# Altium schematic sheet BaseModuleTop.SchDoc
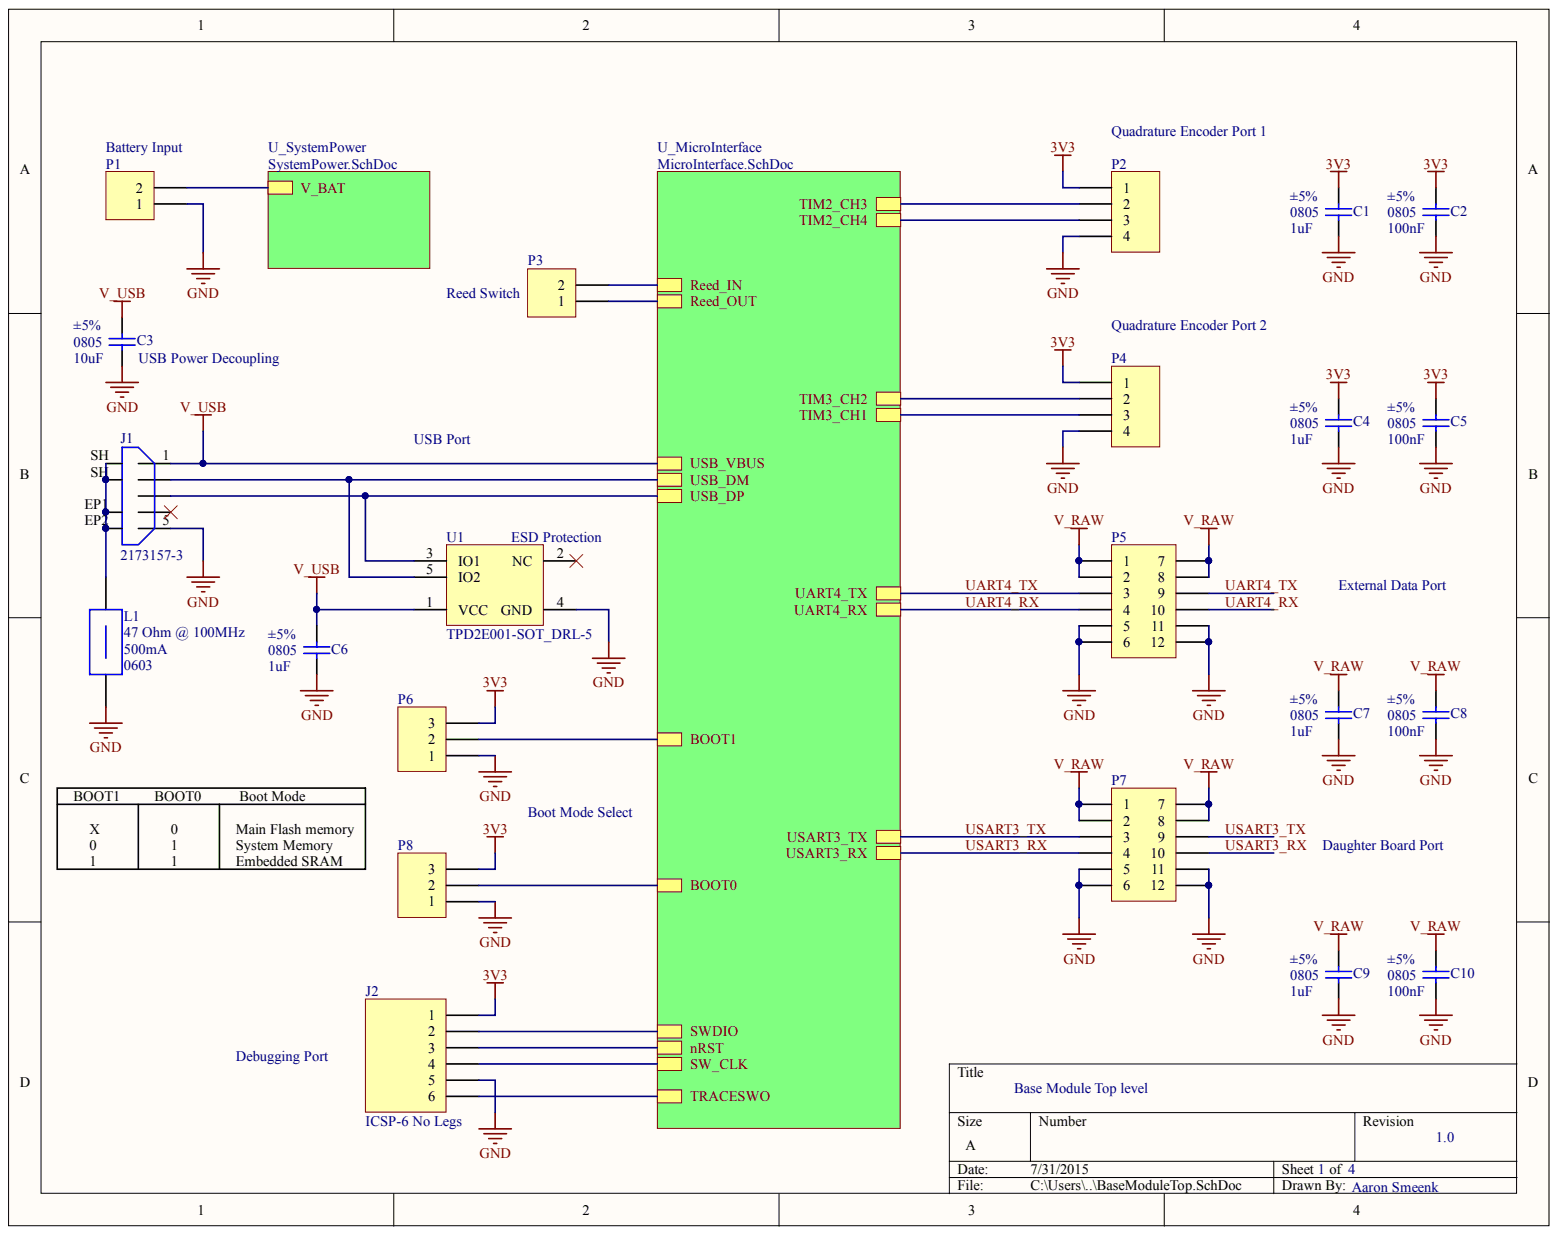
[diagram p0: BaseModuleTop.SchDoc]

## Parts
C1  value 1uF  Case-EIA: 0805  Tolerance: ±5%  [CAPC0805(2012)100_L]  (hidden comment: CAP 1uF 6.3V 0805(2012))
C2  value 100nF  Case-EIA: 0805  Tolerance: ±5%  [CAPC0805(2012)100_L]  (hidden comment: CAP 100nF 6.3V 0805(2012))
C3  value 10uF  Case-EIA: 0805  Tolerance: ±5%  [CAPC0805(2012)100_L]  (hidden comment: CAP 10uF 6.3V 0805(2012))
C4  value 1uF  Case-EIA: 0805  Tolerance: ±5%  [CAPC0805(2012)100_L]  (hidden comment: CAP 1uF 6.3V 0805(2012))
C5  value 100nF  Case-EIA: 0805  Tolerance: ±5%  [CAPC0805(2012)100_L]  (hidden comment: CAP 100nF 6.3V 0805(2012))
C6  value 1uF  Case-EIA: 0805  Tolerance: ±5%  [CAPC0805(2012)100_L]  (hidden comment: CAP 1uF 6.3V 0805(2012))
C7  value 1uF  Case-EIA: 0805  Tolerance: ±5%  [CAPC0805(2012)100_L]  (hidden comment: CAP 1uF 6.3V 0805(2012))
C8  value 100nF  Case-EIA: 0805  Tolerance: ±5%  [CAPC0805(2012)100_L]  (hidden comment: CAP 100nF 6.3V 0805(2012))
C9  value 1uF  Case-EIA: 0805  Tolerance: ±5%  [CAPC0805(2012)100_L]  (hidden comment: CAP 1uF 6.3V 0805(2012))
C10  value 100nF  Case-EIA: 0805  Tolerance: ±5%  [CAPC0805(2012)100_L]  (hidden comment: CAP 100nF 6.3V 0805(2012))
J1  2173157-3  [2173157-3]
J2  ICSP-6 No Legs  [TC2030-IDC-NL]
L1  Current Rating: 500mA  Package / Case: 0603  [LI0603E470R-10]  (hidden comment: LI0603E470R-10)
P1  Header 2  [HDR1X2]  (value not drawn)
P2  Header 4  [HDR1X4]  (value not drawn)
P3  Header 2  [HDR1X2]  (value not drawn)
P4  Header 4  [HDR1X4]  (value not drawn)
P5  Header 6X2A  [HDR2X6_CEN]  (value not drawn)
P6  Header 3  [HDR1X3]  (value not drawn)
P7  Header 6X2A  [HDR2X6_CEN]  (value not drawn)
P8  Header 3  [HDR1X3]  (value not drawn)
U1  TPD2E001-SOT_DRL-5  [TPD2E001DRLR]

## Nets
3V3: C1.2 C2.2 C4.2 C5.2 J2.1 P2.1 P4.1 P6.3 P8.3
GND: C1.1 C2.1 C3.1 C4.1 C5.1 C6.1 C7.1 C8.1 C9.1 C10.1 J1.5 J2.5 L1.1 P1.1 P2.4 P4.4 P5.5 P5.6 P5.11 P5.12 P6.1 P7.5 P7.6 P7.11 P7.12 P8.1 U1.4(GND)
V_RAW: C7.2 C8.2 C9.2 C10.2 P5.1 P5.2 P5.7 P5.8 P7.1 P7.2 P7.7 P7.8
V_USB: C3.2 C6.2 J1.1 U1.1(VCC)
UART4_RX: P5.4 P5.10
UART4_TX: P5.3 P5.9
USART3_RX: P7.4 P7.10
USART3_TX: P7.3 P7.9
NetJ1_2: J1.2 U1.5(IO2)
NetJ1_3: J1.3 U1.3(IO1)
NetJ1_4: J1.4
NetJ1_EP1: J1.EP1 J1.EP2 J1.SH L1.2
NetJ2_2: J2.2
NetJ2_3: J2.3
NetJ2_4: J2.4
NetJ2_6: J2.6
NetP1_2: P1.2
NetP2_2: P2.2
NetP2_3: P2.3
NetP3_1: P3.1
NetP3_2: P3.2
NetP4_2: P4.2
NetP4_3: P4.3
NetP6_2: P6.2
NetP8_2: P8.2
NetU1_2: U1.2(NC)

## Library data of the parts
C1 C4 C6 C7 C9 = CMP-1036-04730-1: CAP 1uF 6.3V ±5% 0805 (2012 Metric) Thickness 1mm SMD; Case-Metric: 2012; Component Kind: Standard; Library Name: Altium Content Vault; Max Thickness: 1 mm; Rated Voltage: 6.3 V; Technology: SMT
C2 C5 C8 C10 = CMP-1036-04390-1: CAP 100nF 6.3V ±5% 0805 (2012 Metric) Thickness 1mm SMD; Case-Metric: 2012; Component Kind: Standard; Library Name: Altium Content Vault; Max Thickness: 1 mm; Rated Voltage: 6.3 V; Technology: SMT
C3 = CMP-1036-04730-1: CAP 10uF 6.3V ±5% 0805 (2012 Metric) Thickness 1mm SMD; Case-Metric: 2012; Component Kind: Standard; Library Name: Altium Content Vault; Max Thickness: 1 mm; Rated Voltage: 6.3 V; Technology: SMT
J1 = 2173157-3: USB Connectors SPLASH PROOF MICRO 5P USB B-TYPE; Brand: TE Connectivity; Gender: Female; Mounting Angle: Right; Number of Contacts: 5; Number of Ports: 1; Component Kind: Standard; Contact Material: Copper Alloy; Contact Plating: Gold; Library Name: schlib.SchLib; Product: Micro USB Type B Connectors; Shell Plating: Tin; Standard: USB 2.0; Termination Style: SMD
J2 = ICSP-6 No Legs: 6 Pin Connector; Component Kind: Standard; Library Name: schlib.SchLib
L1 = LI0603E470R-10: FERRITE CHIP 47 OHMS 500MA 0603; DC Resistance (DCR): 100 mOhm Max; Filter Type: Differential Mode - Single; Impedance @ Frequency: 47 Ohm @ 100MHz; Component Kind: Standard; Height (Max): 0.037" (0.95mm); Library Name: schlib.SchLib
P1 P3 = Header 2: Header, 2-Pin; Component Kind: Standard; LatestRevisionDate: 17-Jul-2002; LatestRevisionNote: Re-released for DXP Platform.; Library Name: Miscellaneous Connectors.IntLib; Signal Integrity: Connector; Publisher: Altium Limited
P2 P4 = Header 4: Header, 4-Pin; Component Kind: Standard; LatestRevisionDate: 17-Jul-2002; LatestRevisionNote: Re-released for DXP Platform.; Library Name: Miscellaneous Connectors.IntLib; Signal Integrity: Connector; Publisher: Altium Limited
P5 P7 = Header 6X2A: Header, 6-Pin, Dual row; Component Kind: Standard; LatestRevisionDate: 17-Jul-2002; LatestRevisionNote: Re-released for DXP Platform.; Library Name: Miscellaneous Connectors.IntLib; Signal Integrity: Connector; Publisher: Altium Limited
P6 P8 = Header 3: Header, 3-Pin; Component Kind: Standard; LatestRevisionDate: 17-Jul-2002; LatestRevisionNote: Re-released for DXP Platform.; Library Name: Miscellaneous Connectors.IntLib; Signal Integrity: Connector; Publisher: Altium Limited
U1 = TPD2E001DRLR: TVS DIODE 5.5VWM 100VC SOT5; Bidirectional Channels: 2; Power Line Protection: Yes; Voltage - Breakdown (Min): 11V; Voltage - Clamping (Max) @ Ipp: 100V; Voltage - Reverse Standoff (Typ): 5.5V (Max); Component Kind: Standard; Library Name: schlib.SchLib; Operating Temperature: -40°C ~ 85°C (TA); Package / Case: SOT-553; Supplier Device Package: 5-SOT; Type: Steering (Rail to Rail)
